# Altium schematic sheet Isolation_&_Bal_LEDs_Plus.SchDoc(Isolation_&_Bal_LEDs_Plus)
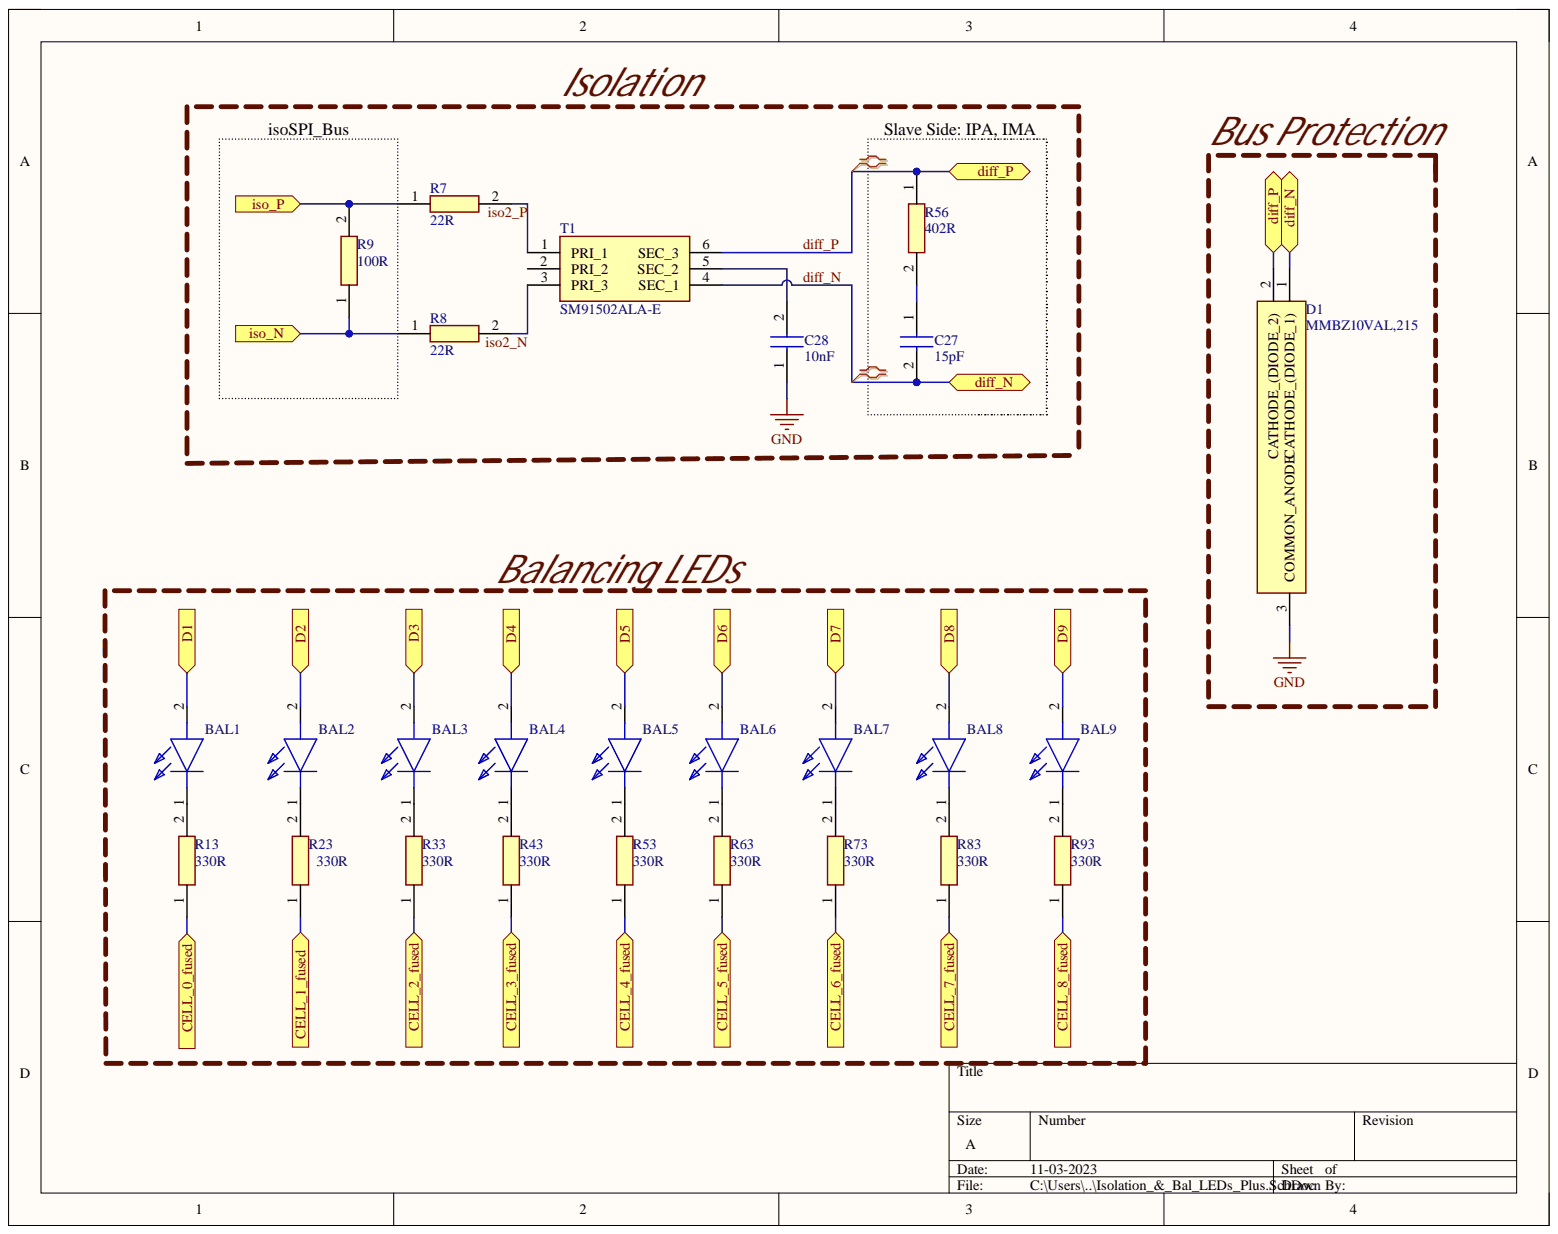
[diagram p0: Isolation_&_Bal_LEDs_Plus.SchDoc]

## Parts
BAL1  LTST-C170KRKT  [LEDC1608X50N]  (value not drawn)
BAL2  LTST-C170KRKT  [LEDC1608X50N]  (value not drawn)
BAL3  LTST-C170KRKT  [LEDC1608X50N]  (value not drawn)
BAL4  LTST-C170KRKT  [LEDC1608X50N]  (value not drawn)
BAL5  LTST-C170KRKT  [LEDC1608X50N]  (value not drawn)
BAL6  LTST-C170KRKT  [LEDC1608X50N]  (value not drawn)
BAL7  LTST-C170KRKT  [LEDC1608X50N]  (value not drawn)
BAL8  LTST-C170KRKT  [LEDC1608X50N]  (value not drawn)
BAL9  LTST-C170KRKT  [LEDC1608X50N]  (value not drawn)
C27  15pF  [CAPC1608X90N]
C28  10nF  [CAPC1608X90N]
D1  MMBZ10VAL,215  [SOT95P260X110-3N]
R7  22R  [RESC1608X55N]
R8  22R  [RESC1608X55N]
R9  100R  [RESC1608X55N]
R13  330R  [RESC1608X55N]
R23  330R  [RESC1608X55N]
R33  330R  [RESC1608X55N]
R43  330R  [RESC1608X55N]
R53  330R  [RESC1608X55N]
R56  402R  [RESC1608X55N]
R63  330R  [RESC1608X55N]
R73  330R  [RESC1608X55N]
R83  330R  [RESC1608X55N]
R93  330R  [RESC1608X55N]
T1  SM91502ALA-E  [SM91502ALAE]

## Ports
CELL_0_fused, CELL_1_fused, CELL_2_fused, CELL_3_fused, CELL_4_fused, CELL_5_fused, CELL_6_fused, CELL_7_fused, CELL_8_fused, D1, D2, D3, D4, D5, D6, D7, D8, D9, diff_N, diff_P, iso_N, iso_P

## Nets
GND: C28.1 D1.3
CELL_0_fused: R13.1 ; port CELL_0_fused
CELL_1_fused: R23.1 ; port CELL_1_fused
CELL_2_fused: R33.1 ; port CELL_2_fused
CELL_3_fused: R43.1 ; port CELL_3_fused
CELL_4_fused: R53.1 ; port CELL_4_fused
CELL_5_fused: R63.1 ; port CELL_5_fused
CELL_6_fused: R73.1 ; port CELL_6_fused
CELL_7_fused: R83.1 ; port CELL_7_fused
CELL_8_fused: R93.1 ; port CELL_8_fused
D1: BAL1.2 ; port D1
D2: BAL2.2 ; port D2
D3: BAL3.2 ; port D3
D4: BAL4.2 ; port D4
D5: BAL5.2 ; port D5
D6: BAL6.2 ; port D6
D7: BAL7.2 ; port D7
D8: BAL8.2 ; port D8
D9: BAL9.2 ; port D9
diff_N: C27.2 D1.1 T1.4(SEC_1) ; port diff_N
diff_P: D1.2 R56.1 T1.6(SEC_3) ; port diff_P
iso2_N: R8.2 T1.3(PRI_3)
iso2_P: R7.2 T1.1(PRI_1)
iso_N: R8.1 R9.1 ; port iso_N
iso_P: R7.1 R9.2 ; port iso_P
NetBAL1_1: BAL1.1 R13.2
NetBAL2_1: BAL2.1 R23.2
NetBAL3_1: BAL3.1 R33.2
NetBAL4_1: BAL4.1 R43.2
NetBAL5_1: BAL5.1 R53.2
NetBAL6_1: BAL6.1 R63.2
NetBAL7_1: BAL7.1 R73.2
NetBAL8_1: BAL8.1 R83.2
NetBAL9_1: BAL9.1 R93.2
NetC27_1: C27.1 R56.2
NetC28_2: C28.2 T1.5(SEC_2)

Not connected: T1 2(PRI_2)

## Library data of the parts
BAL1-BAL9 = LTST-C170KRKT: LED; Manufacturer_Name: Lite-On; Arrow Part Number: LTST-C170KRKT; Arrow Price/Stock: https://www.arrow.com/en/products/ltst-c170krkt/lite-on-technology?region=nac; Component Kind: Standard; Datasheet Link: https://componentsearchengine.com/Datasheets/1/LTST-C170KRKT.pdf; Height: 1.2mm; Library Name: BMS_schlib.SchLib; Manufacturer_Part_Number: LTST-C170KRKT; Mouser Part Number: 859-LTST-C170KRKT; Mouser Price/Stock: https://www.mouser.co.uk/ProductDetail/Lite-On/LTST-C170KRKT?qs=NUb82WqeCyrVOID%2Fxt4rgA%3D%3D
C27 C28 = 0402YC104MAT2A: Capacitor; Manufacturer_Name: AVX; Component Kind: Standard; Datasheet Link: http://www.mouser.com/catalog/supplier/library/pdf/AVXSurfaceMountCeramic.pdf; Height: 0.56mm; Library Name: BMS_schlib.SchLib; Manufacturer_Part_Number: 0402YC104MAT2A; Mouser Part Number: 581-0402YC104MAT2A; Mouser Price/Stock: https://www.mouser.co.uk/ProductDetail/AVX/0402YC104MAT2A?qs=O2%2FeDh0sX9yiCaLwpXy1bw%3D%3D
D1 = MMBZ10VAL,215: TVS Diode (Uni-directional); Manufacturer_Name: Nexperia; Arrow Part Number: MMBZ10VAL,215; Arrow Price/Stock: https://www.arrow.com/en/products/mmbz10val215/nexperia?region=nac; Component Kind: Standard; Datasheet Link: https://assets.nexperia.com/documents/data-sheet/MMBZXAL_SER.pdf; Height: 1.1mm; Manufacturer_Part_Number: MMBZ10VAL,215; Mouser Part Number: 771-MMBZ10VAL215; Mouser Price/Stock: https://www.mouser.co.uk/ProductDetail/Nexperia/MMBZ10VAL215?qs=MWRe%252BjyhxRuJCKQ9iUaiug%3D%3D
R7-R9 R13 R23 R33 R43 R53 R56 R63 R73 R83 R93 = CPF0201D100RE1: Resistor; Manufacturer_Name: TE Connectivity; Arrow Part Number: CPF0201D100RE1; Arrow Price/Stock: https://www.arrow.com/en/products/cpf0201d100re1/te-connectivity?region=nac; Component Kind: Standard; Datasheet Link: http://www.te.com/commerce/DocumentDelivery/DDEController?Action=showdoc&DocId=Data+Sheet%7F1773200-1%7FA%7Fpdf%7FEnglish%7FENG_DS_1773200-1_A.pdf%7F4-2176215-6; Height: 0.28mm; Library Name: BMS_schlib.SchLib; Manufacturer_Part_Number: CPF0201D100RE1; Mouser Part Number: 279-CPF0201D100RE1; Mouser Price/Stock: https://www.mouser.co.uk/ProductDetail/TE-Connectivity-Holsworthy/CPF0201D100RE1?qs=gt1LBUVyoHlmoWock10t3Q%3D%3D
T1 = SM91502ALA-E: Transformer; Manufacturer_Name: Bourns; Arrow Part Number: SM91502ALA-E; Arrow Price/Stock: https://www.arrow.com/en/products/sm91502ala-e/bourns?region=nac; Component Kind: Standard; Datasheet Link: http://www.bourns.com/docs/Product-Datasheets/SM91502ALA.pdf; Height: 5.72mm; Library Name: BMS_schlib.SchLib; Manufacturer_Part_Number: SM91502ALA-E; Mouser Part Number: 652-SM91502ALA-E; Mouser Price/Stock: https://www.mouser.co.uk/ProductDetail/Bourns/SM91502ALA-E?qs=Wj%2FVkw3K%252BMDeDcOMgFFlnw%3D%3D
